# Altium schematic sheet drop.SchDoc
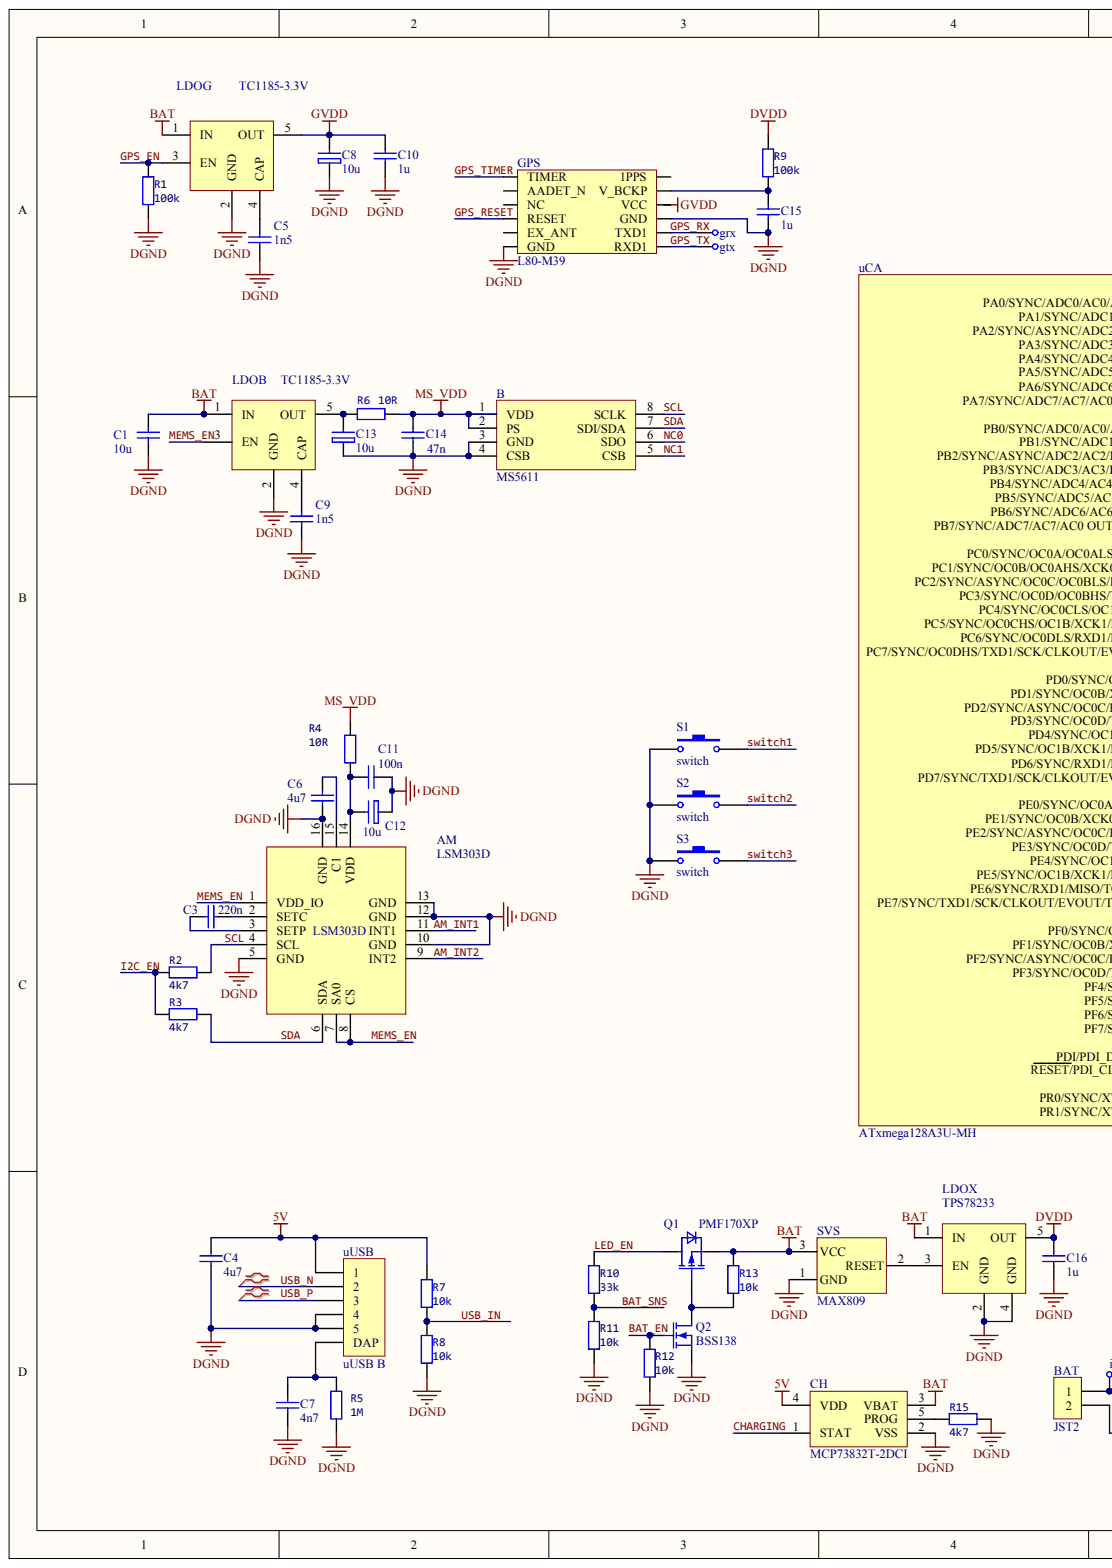
[diagram p0: drop.SchDoc, left]
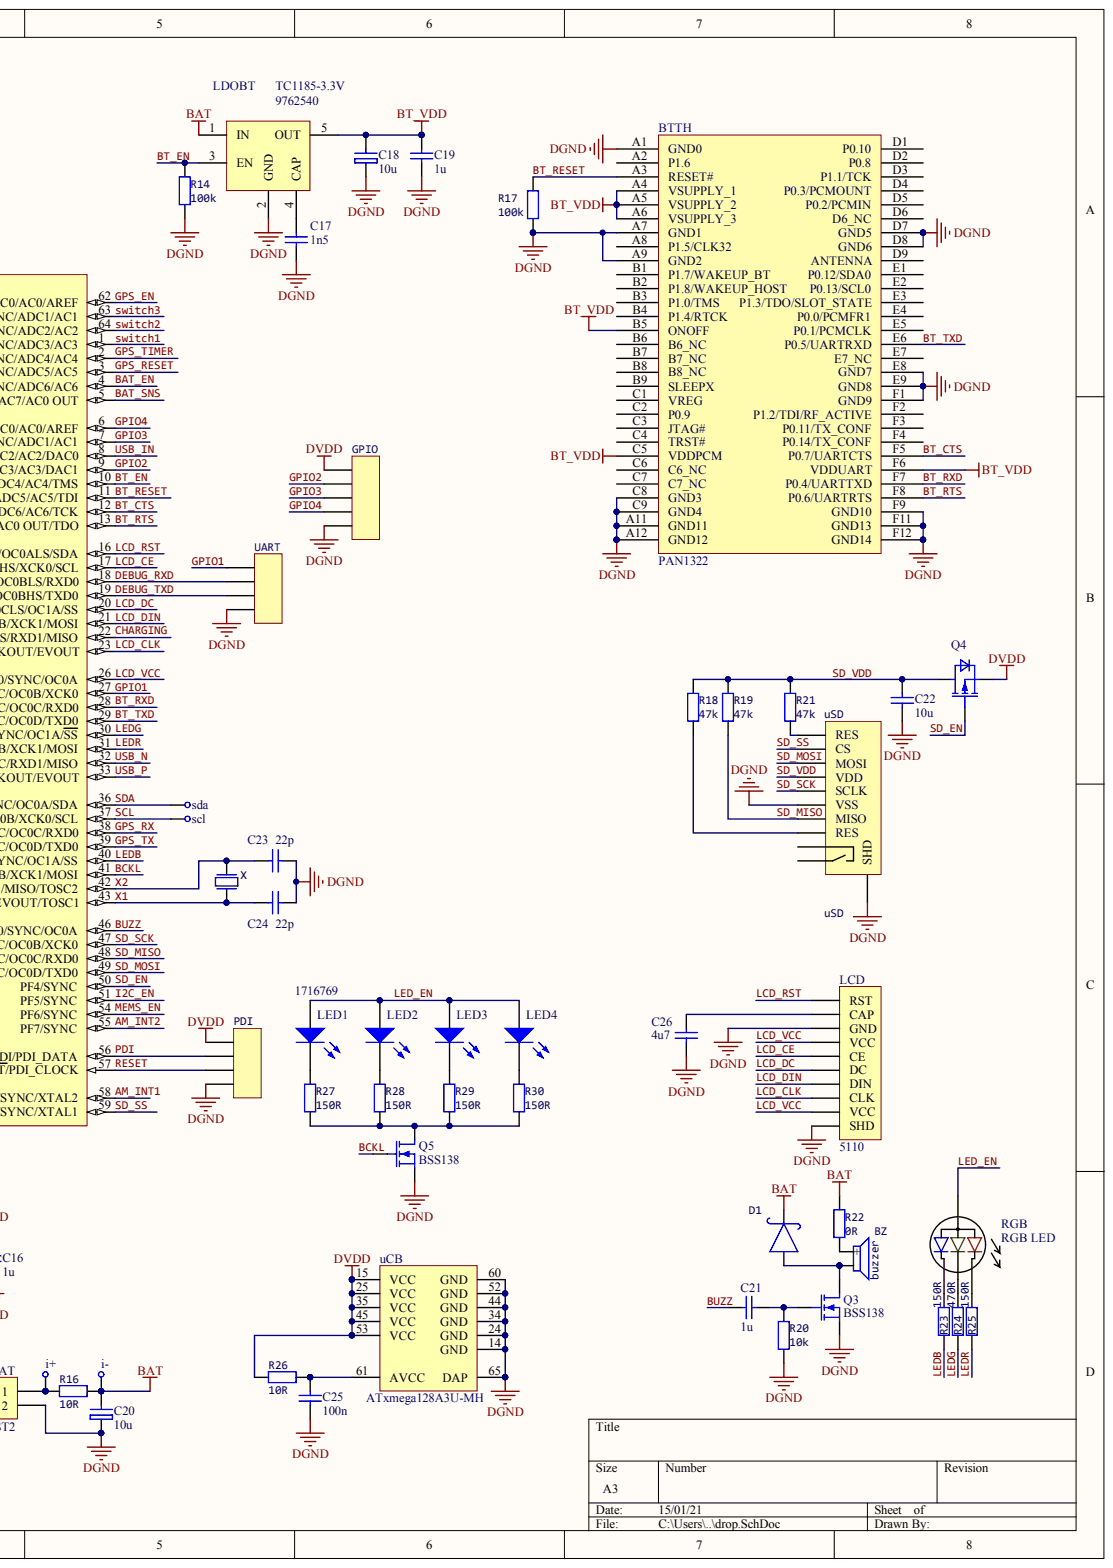
[diagram p1: drop.SchDoc, right]

## Parts
AM  LSM303D
B  MS5611
BAT  JST2
BTTH  PAN1322
BZ  buzzer
C1  10u
C3  220n
C4  4u7
C5  value 1n5  (hidden comment: C603)
C6  4u7
C7  4n7
C8  value 10u  (hidden comment: C1206T)
C9  value 1n5  (hidden comment: C603)
C10  1u
C11  100n
C12  value 10u  (hidden comment: C1206T)
C13  value 10u  (hidden comment: C1206T)
C14  value 47n  (hidden comment: C1206)
C15  value 1u  (hidden comment: C603)
C16  1u
C17  value 1n5  (hidden comment: C603)
C18  value 10u  (hidden comment: C1206T)
C19  1u
C20  value 10u  (hidden comment: C1206T)
C21  1u
C22  10u
C23  value 22p  (hidden comment: C603)
C24  value 22p  (hidden comment: C603)
C25  100n
C26  4u7
CH  MCP73832T-2DCI
D1  CD0603  (value not drawn)
GPIO  GPIO
GPS  L80-M39
grx  test point  (value not drawn)
gtx  test point  (value not drawn)
i+  test point  (value not drawn)
i-  test point  (value not drawn)
LCD  5110
LDOB  TC1185-3.3V
LDOBT  TC1185-3.3V  Supplier Part Number 1: 9762540
LDOG  TC1185-3.3V
LDOX  TPS78233
LED1  Supplier Part Number 1: 1716769  (hidden comment: LED 0603)
LED2  LED 0603  (value not drawn)
LED3  LED 0603  (value not drawn)
LED4  LED 0603  (value not drawn)
PDI  PDI
Q1  PMF170XP
Q2  BSS138
Q3  BSS138
Q4  PMF170XP
Q5  BSS138
R1  100k
R2  4k7
R3  4k7
R4  10R
R5  1M
R6  10R
R7  10k
R8  10k
R9  100k
R10  33k
R11  10k
R12  10k
R13  10k
R14  100k
R15  4k7
R16  10R
R17  100k
R18  47k
R19  47k
R20  10k
R21  47k
R22  0R
R23  150R
R24  470R
R25  150R
R26  10R
R27  150R
R28  150R
R29  150R
R30  150R
RGB  RGB LED
S1  switch
S2  switch
S3  switch
scl  test point  (value not drawn)
sda  test point  (value not drawn)
SVS  MAX809
UART  PDI  (value not drawn)
uC  ATxmega128A3U-MH  (parts A,B)
uSD  uSD
uUSB  uUSB B
X  Crystal  (value not drawn)

## Nets
5V: C4.1 CH.4(VDD) R7.1 uUSB.1
BAT: C1.1 C20.1 CH.3(VBAT) D1.2 i-.1 LDOB.1(IN) LDOBT.1(IN) LDOG.1(IN) LDOX.1(IN) Q1.2 R13.2 R16.2 R22.1 SVS.3
BT_VDD: BTTH.A4(VSUPPLY_1) BTTH.A5(VSUPPLY_2) BTTH.A6(VSUPPLY_3) BTTH.B5(ONOFF) BTTH.C5(VDDPCM) BTTH.F6(VDDUART) C18.1 C19.2 LDOBT.5(OUT)
DGND: AM.5(GND) AM.10(GND) AM.12(GND) AM.13(GND) AM.16(GND) B.3(GND) B.4(CSB) BAT.2 BTTH.A1(GND0) BTTH.A7(GND1) BTTH.A9(GND2) BTTH.A11(GND11) BTTH.A12(GND12) BTTH.C8(GND3) BTTH.C9(GND4) BTTH.D7(GND5) BTTH.D8(GND6) BTTH.E8(GND7) BTTH.E9(GND8) BTTH.F1(GND9) BTTH.F9(GND10) BTTH.F11(GND13) BTTH.F12(GND14) C1.2 C4.2 C5.1 C6.1 C7.1 C8.2 C9.1 C10.1 C11.1 C12.2 C13.2 C14.1 C15.1 C16.1 C17.1 C18.2 C19.1 C20.2 C22.2 C23.2 C24.2 C25.1 C26.1 CH.2(VSS) GPIO.5 GPS.3(GND) GPS.12(GND) LCD.0(SHD) LCD.3(GND) LDOB.2(GND) LDOBT.2(GND) LDOG.2(GND) LDOX.2(GND) LDOX.4(GND) PDI.4 Q2.2 Q3.2 Q5.2 R1.1 R5.2 R8.2 R11.1 R12.1 R14.1 R15.1 R17.2 R20.1 S1.1 S2.1 S3.1 SVS.1 UART.4 uC.14(GND) uC.24(GND) uC.34(GND) uC.44(GND) uC.52(GND) uC.60(GND) uC.65(DAP) uSD.0(SHD) uSD.6(VSS) uUSB.4 uUSB.5
DVDD: C16.2 GPIO.1 LDOX.5(OUT) PDI.1 Q4.2 R9.2 R26.1 uC.15(VCC) uC.25(VCC) uC.35(VCC) uC.45(VCC) uC.53(VCC)
GVDD: C8.1 C10.2 GPS.4(VCC) LDOG.5(OUT)
MS_VDD: B.1(VDD) B.2(PS) C14.2 R4.1 R6.1
AM_INT1: AM.11(INT1) uC.58(PR0/SYNC/XTAL2)
AM_INT2: AM.9(INT2) uC.55(PF7/SYNC)
BAT_EN: Q2.1 R12.2 uC.4(PA6/SYNC/ADC6/AC6)
BAT_SNS: R10.1 R11.2 uC.5(PA7/SYNC/ADC7/AC7/AC0_OUT)
BCKL: Q5.1 uC.41(PE5/SYNC/OC1B/XCK1/MOSI)
BT_CTS: BTTH.F5(P0.7/UARTCTS) uC.12(PB6/SYNC/ADC6/AC6/TCK)
BT_EN: LDOBT.3(EN) R14.2 uC.10(PB4/SYNC/ADC4/AC4/TMS)
BT_RESET: BTTH.A3(RESET#) R17.1 uC.11(PB5/SYNC/ADC5/AC5/TDI)
BT_RTS: BTTH.F8(P0.6/UARTRTS) uC.13(PB7/SYNC/ADC7/AC7/AC0_OUT/TDO)
BT_RXD: BTTH.F7(P0.4/UARTTXD) uC.28(PD2/SYNC/ASYNC/OC0C/RXD0)
BT_TXD: BTTH.E6(P0.5/UARTRXD) uC.29(PD3/SYNC/OC0D/TXD0)
BUZZ: C21.2 uC.46(PF0/SYNC/OC0A)
CHARGING: CH.1(STAT) uC.22(PC6/SYNC/OC0DLS/RXD1/MISO)
DEBUG_RXD: UART.2 uC.18(PC2/SYNC/ASYNC/OC0C/OC0BLS/RXD0)
DEBUG_TXD: UART.3 uC.19(PC3/SYNC/OC0D/OC0BHS/TXD0)
GPIO1: UART.1 uC.27(PD1/SYNC/OC0B/XCK0)
GPIO2: GPIO.2 uC.9(PB3/SYNC/ADC3/AC3/DAC1)
GPIO3: GPIO.3 uC.7(PB1/SYNC/ADC1/AC1)
GPIO4: GPIO.4 uC.6(PB0/SYNC/ADC0/AC0/AREF)
GPS_EN: LDOG.3(EN) R1.2 uC.62(PA0/SYNC/ADC0/AC0/AREF)
GPS_RESET: GPS.10(RESET) uC.3(PA5/SYNC/ADC5/AC5)
GPS_RX: GPS.2(TXD1) grx.1 uC.38(PE2/SYNC/ASYNC/OC0C/RXD0)
GPS_TIMER: GPS.7(TIMER) uC.2(PA4/SYNC/ADC4/AC4)
GPS_TX: GPS.1(RXD1) gtx.1 uC.39(PE3/SYNC/OC0D/TXD0)
I2C_EN: R2.1 R3.1 uC.51(PF5/SYNC)
LCD_CE: LCD.5(CE) uC.17(PC1/SYNC/OC0B/OC0AHS/XCK0/SCL)
LCD_CLK: LCD.8(CLK) uC.23(PC7/SYNC/OC0DHS/TXD1/SCK/CLKOUT/EVOUT)
LCD_DC: LCD.6(DC) uC.20(PC4/SYNC/OC0CLS/OC1A/SS)
LCD_DIN: LCD.7(DIN) uC.21(PC5/SYNC/OC0CHS/OC1B/XCK1/MOSI)
LCD_RST: LCD.1(RST) uC.16(PC0/SYNC/OC0A/OC0ALS/SDA)
LCD_VCC: LCD.4(VCC) LCD.9(VCC) uC.26(PD0/SYNC/OC0A)
LED_EN: LED1.1 LED2.1 LED3.1 LED4.1 Q1.3 R10.2 RGB.1
LEDB: R23.1 uC.40(PE4/SYNC/OC1A/SS)
LEDG: R24.1 uC.30(PD4/SYNC/OC1A/SS)
LEDR: R25.1 uC.31(PD5/SYNC/OC1B/XCK1/MOSI)
MEMS_EN: AM.1(VDD_IO) AM.7(SA0) AM.8(CS) LDOB.3(EN) uC.54(PF6/SYNC)
NC0: B.6(SDO)
NC1: B.5(CSB)
PDI: PDI.2 uC.56(PDI/PDI_DATA)
RESET: PDI.3 uC.57(RESET/PDI_CLOCK)
SCL: AM.4(SCL) B.8(SCLK) R2.2 scl.1 uC.37(PE1/SYNC/OC0B/XCK0/SCL)
SD_EN: Q4.1 uC.50(PF4/SYNC)
SD_MISO: R19.1 uC.48(PF2/SYNC/ASYNC/OC0C/RXD0) uSD.7(MISO)
SD_MOSI: uC.49(PF3/SYNC/OC0D/TXD0) uSD.3(MOSI)
SD_SCK: uC.47(PF1/SYNC/OC0B/XCK0) uSD.5(SCLK)
SD_SS: uC.59(PR1/SYNC/XTAL1) uSD.2(CS)
SD_VDD: C22.1 Q4.3 R18.2 R19.2 R21.2 uSD.4(VDD)
SDA: AM.6(SDA) B.7(SDI/SDA) R3.2 sda.1 uC.36(PE0/SYNC/OC0A/SDA)
SWITCH1: S1.2 uC.1(PA3/SYNC/ADC3/AC3)
SWITCH2: S2.2 uC.64(PA2/SYNC/ASYNC/ADC2/AC2)
SWITCH3: S3.2 uC.63(PA1/SYNC/ADC1/AC1)
USB_IN: R7.2 R8.1 uC.8(PB2/SYNC/ASYNC/ADC2/AC2/DAC0)
USB_N: uC.32(PD6/SYNC/RXD1/MISO) uUSB.2
USB_P: uC.33(PD7/SYNC/TXD1/SCK/CLKOUT/EVOUT) uUSB.3
X1: C24.1 uC.43(PE7/SYNC/TXD1/SCK/CLKOUT/EVOUT/TOSC1) X.1
X2: C23.1 uC.42(PE6/SYNC/RXD1/MISO/TOSC2) X.2
NetAM_2: AM.2(SETC) C3.1
NetAM_3: AM.3(SETP) C3.2
NetAM_14: AM.14(VDD) C11.2 C12.1 R4.2
NetAM_15: AM.15(C1) C6.2
NetBAT_1: BAT.1 i+.1 R16.1
NetBZ_1: BZ.1 R22.2
NetBZ_2: BZ.2 D1.1 Q3.3
NetC5_2: C5.2 LDOG.4(CAP)
NetC7_2: C7.2 R5.1 uUSB.0(DAP)
NetC9_2: C9.2 LDOB.4(CAP)
NetC13_1: C13.1 LDOB.5(OUT) R6.2
NetC15_2: C15.2 GPS.5(V_BCKP) R9.1
NetC17_2: C17.2 LDOBT.4(CAP)
NetC21_1: C21.1 Q3.1 R20.2
NetC25_2: C25.2 R26.2 uC.61(AVCC)
NetC26_2: C26.2 LCD.2(CAP)
NetCH_5: CH.5(PROG) R15.2
NetLDOX_3: LDOX.3(EN) SVS.2
NetLED1_2: LED1.2 R27.2
NetLED2_2: LED2.2 R28.2
NetLED3_2: LED3.2 R29.2
NetLED4_2: LED4.2 R30.2
NetQ1_1: Q1.1 Q2.3 R13.1
NetQ5_3: Q5.3 R27.1 R28.1 R29.1 R30.1
NetR18_1: R18.1 uSD.8(RES)
NetR21_1: R21.1 uSD.1(RES)
NetR23_2: R23.2 RGB.2
NetR24_2: R24.2 RGB.4
NetR25_2: R25.2 RGB.3

Not connected: BTTH A2(P1.6) A8(P1.5/CLK32) B1(P1.7/WAKEUP_BT) B2(P1.8/WAKEUP_HOST) B3(P1.0/TMS) B4(P1.4/RTCK) B6(B6_NC) B7(B7_NC) B8(B8_NC) B9(SLEEPX) C1(VREG) C2(P0.9) C3(JTAG#) C4(TRST#) C6(C6_NC) C7(C7_NC) D1(P0.10) D2(P0.8) D3(P1.1/TCK) D4(P0.3/PCMOUNT) D5(P0.2/PCMIN) D6(D6_NC) D9(ANTENNA) E1(P0.12/SDA0) E2(P0.13/SCL0) E3(P1.3/TDO/SLOT_STATE) E4(P0.0/PCMFR1) E5(P0.1/PCMCLK) E7(E7_NC) F2(P1.2/TDI/RF_ACTIVE) F3(P0.11/TX_CONF) F4(P0.14/TX_CONF); GPS 6(1PPS) 8(AADET_N) 9(NC) 11(EX_ANT); uSD 9 10

## Library data of the parts
CH = (no symbol name): Manufacturer: MICROCHIP; Manufacturer Part Number: MCP73832T-2DCI/OT; Supplier 1: Farnell; ComponentLink1URL: http://ww1.microchip.com/downloads/en/DeviceDoc/21984e.pdf; ComponentLink2URL: http://www.farnell.com/cad/1545969.zip; Supplier Part Number 1: 1834892
D1 = (no symbol name): Manufacturer: KINGBRIGHT; Manufacturer Part Number: KPA-3010SECK-J3; ComponentLink1URL: http://www.farnell.com/datasheets/1718708.pdf; Supplier 1: Farnell; Supplier Part Number 1: 2211954
Q1 Q4 = (no symbol name): Manufacturer: NXP; Supplier 1: Farnell; Manufacturer Part Number: PMF170XP; RoHS: YES; Stock 1: 12627; Pricing 1: 1=0,113, 25=0,107, 100=0,1, 250=0,099, 500=0,091 (EUR); ComponentLink1URL: http://www.nxp.com/documents/data_sheet/PMF170XP.pdf; ComponentLink1Description: http://www.nxp.com/documents/data_sheet/PMF170XP.pdf; Supplier Part Number 1: 2069557
SVS = (no symbol name): Manufacturer: ON SEMICONDUCTOR; Manufacturer Part Number: MAX809TTRG; Supplier 1: Farnell; RoHS: YES; Stock 1: 7179; Pricing 1: 1=0,4, 25=0,36, 50=0,35, 100=0,25, 500=0,21 (EUR); ComponentLink1URL: http://www.farnell.com/datasheets/87991.pdf; ComponentLink1Description: http://www.farnell.com/datasheets/87991.pdf; ComponentLink2URL: http://www.farnell.com/cad/1549711.zip; ComponentLink2Description: http://www.farnell.com/cad/1549711.zip; Supplier Part Number 1: 1652405
uC = (no symbol name): Manufacturer: ATMEL; Manufacturer Part Number: ATXMEGA128A3U-MH; ComponentLink1URL: http://www.farnell.com/datasheets/1503981.pdf; ComponentLink2URL: http://www.farnell.com/cad/1774125.zip; ComponentLink3URL: http://www.farnell.com/datasheets/1699481.pdf; Supplier 1: Farnell; Supplier Part Number 1: 2066304
uSD = (no symbol name): Manufacturer: HRS (HIROSE); Manufacturer Part Number: DM3D-SF; ComponentLink1Description: http://www.farnell.com/datasheets/1697167.pdf; Supplier 1: Farnell; Supplier Part Number 1: 1764377
X = (no symbol name): Manufacturer: ABRACON; Manufacturer Part Number: ABS07-32.768KHZ-T; Supplier 1: Farnell; ComponentLink1URL: http://www.farnell.com/datasheets/1700986.pdf; Supplier Part Number 1: 2101347
AM B BAT BTTH BZ C1 C3-C26 GPIO GPS grx gtx i+ i- LCD LDOB LDOBT LDOG LDOX LED1-LED4 PDI Q2 Q3 Q5 R1-R30 RGB S1-S3 scl sda UART uUSB = (no symbol name)
  B: Supplier 1: Farnell; Supplier Part Number 1: 2362662
  BAT: LatestRevisionDate: 17-Jul-2002; LatestRevisionNote: Re-released for DXP Platform.; Supplier 1: Farnell; Supplier Part Number 1: 1679117
  BZ: Supplier 1: Farnell; Supplier Part Number 1: 1801087
  C1 C22: LatestRevisionDate: 29-May-2009; LatestRevisionNote: IPC-7351 Footprint Added.; PackageDescription: Chip Capacitor; Value: 10u; Supplier 1: Farnell; Supplier Part Number 1: 1759136
  C3: LatestRevisionDate: 29-May-2009; LatestRevisionNote: IPC-7351 Footprint Added.; PackageDescription: Chip Capacitor; Value: 220n; Supplier 1: Farnell; Supplier Part Number 1: 1759019
  C4 C6 C26: LatestRevisionDate: 29-May-2009; LatestRevisionNote: IPC-7351 Footprint Added.; PackageDescription: Chip Capacitor; Value: 4u7; Supplier 1: Farnell; Supplier Part Number 1: 1759478
  C5 C9 C14 C15 C17 C23 C24: LatestRevisionDate: 29-May-2009; LatestRevisionNote: IPC-7351 Footprint Added.; PackageDescription: Chip Capacitor
  C7: LatestRevisionDate: 29-May-2009; LatestRevisionNote: IPC-7351 Footprint Added.; PackageDescription: Chip Capacitor; Value: 4n7; Supplier 1: Farnell; Supplier Part Number 1: 1907312
  C8 C12 C13 C18 C20: LatestRevisionDate: 29-May-2009; LatestRevisionNote: IPC-7351 Footprint Added.; PackageDescription: Chip Capacitor; Supplier 1: Farnell; Supplier Part Number 1: 2250086
  C10 C16 C19 C21: LatestRevisionDate: 29-May-2009; LatestRevisionNote: IPC-7351 Footprint Added.; PackageDescription: Chip Capacitor; Value: 1u
  C11 C25: LatestRevisionDate: 29-May-2009; LatestRevisionNote: IPC-7351 Footprint Added.; PackageDescription: Chip Capacitor; Value: 100n
  LDOB LDOG: Supplier 1: Farnell; Supplier Part Number 1: 9762540
  LDOBT: Supplier 1: Farnell
  LDOX: Supplier 1: Farnell; Supplier Part Number 1: 1710835
  LED1: Published: 8-Jun-2000; LatestRevisionDate: 08-Jul-2005; LatestRevisionNote: Mech Layer 1 removed.; PackageReference: LED-0; PackageDescription: LED; 2 Leads; Supplier 1: Farnell
  LED2-LED4: Published: 8-Jun-2000; LatestRevisionDate: 08-Jul-2005; LatestRevisionNote: Mech Layer 1 removed.; PackageReference: LED-0; PackageDescription: LED; 2 Leads
  Q2 Q3 Q5: Published: 17-Dec-2003; DatasheetVersion: Mar-96; PackageVersion: 1996; PackageReference: SOT23; PackageDescription: SOT-23; 3 Leads; Body 3.1 x 2.5 mm, inc. leads (LxW max), Alternate Lead Configuration; ComponentLink1Description: Manufacturer Link; ComponentLink1URL: http://www.zetex.com/; ComponentLink2Description: Datasheet; ComponentLink2URL: www.zetex.com/3.0/pdf/BSS138.pdf; Supplier 1: Farnell; Supplier Part Number 1: 1907611
  R1 R9 R14 R17: Value: 100k; Manufacturer: *; ComponentLink1Description: Datasheet
  R2 R3 R15: Value: 4k7; Manufacturer: *; ComponentLink1Description: Datasheet
  R4 R6 R16 R26: Value: 10R; Manufacturer: *; ComponentLink1Description: Datasheet
  R5: Value: 1M; Manufacturer: *; ComponentLink1Description: Datasheet; Supplier 1: Farnell; Supplier Part Number 1: 2078928
  R7 R8 R11-R13 R20: Value: 10k; Manufacturer: *; ComponentLink1Description: Datasheet
  R10: Value: 33k; Manufacturer: *; ComponentLink1Description: Datasheet
  R18 R19 R21: Value: 47k; Manufacturer: *; ComponentLink1Description: Datasheet
  R22: Value: 0R; Manufacturer: *; ComponentLink1Description: Datasheet
  R23 R25 R27-R30: Value: 150R; Manufacturer: *; ComponentLink1Description: Datasheet
  R24: Value: 470R; Manufacturer: *; ComponentLink1Description: Datasheet
  RGB: Supplier 1: Farnell; Supplier Part Number 1: 2322115
  S1: Supplier 1: Farnell; Supplier Part Number 1: 9471898
  uUSB: Supplier 1: Farnell; Supplier Part Number 1: 2311903
  BAT LED1-LED4 Q2 Q3 Q5: Publisher: Altium Limited
